# Altium schematic sheet CAN_RS485.SchDoc(CAN_RS485)
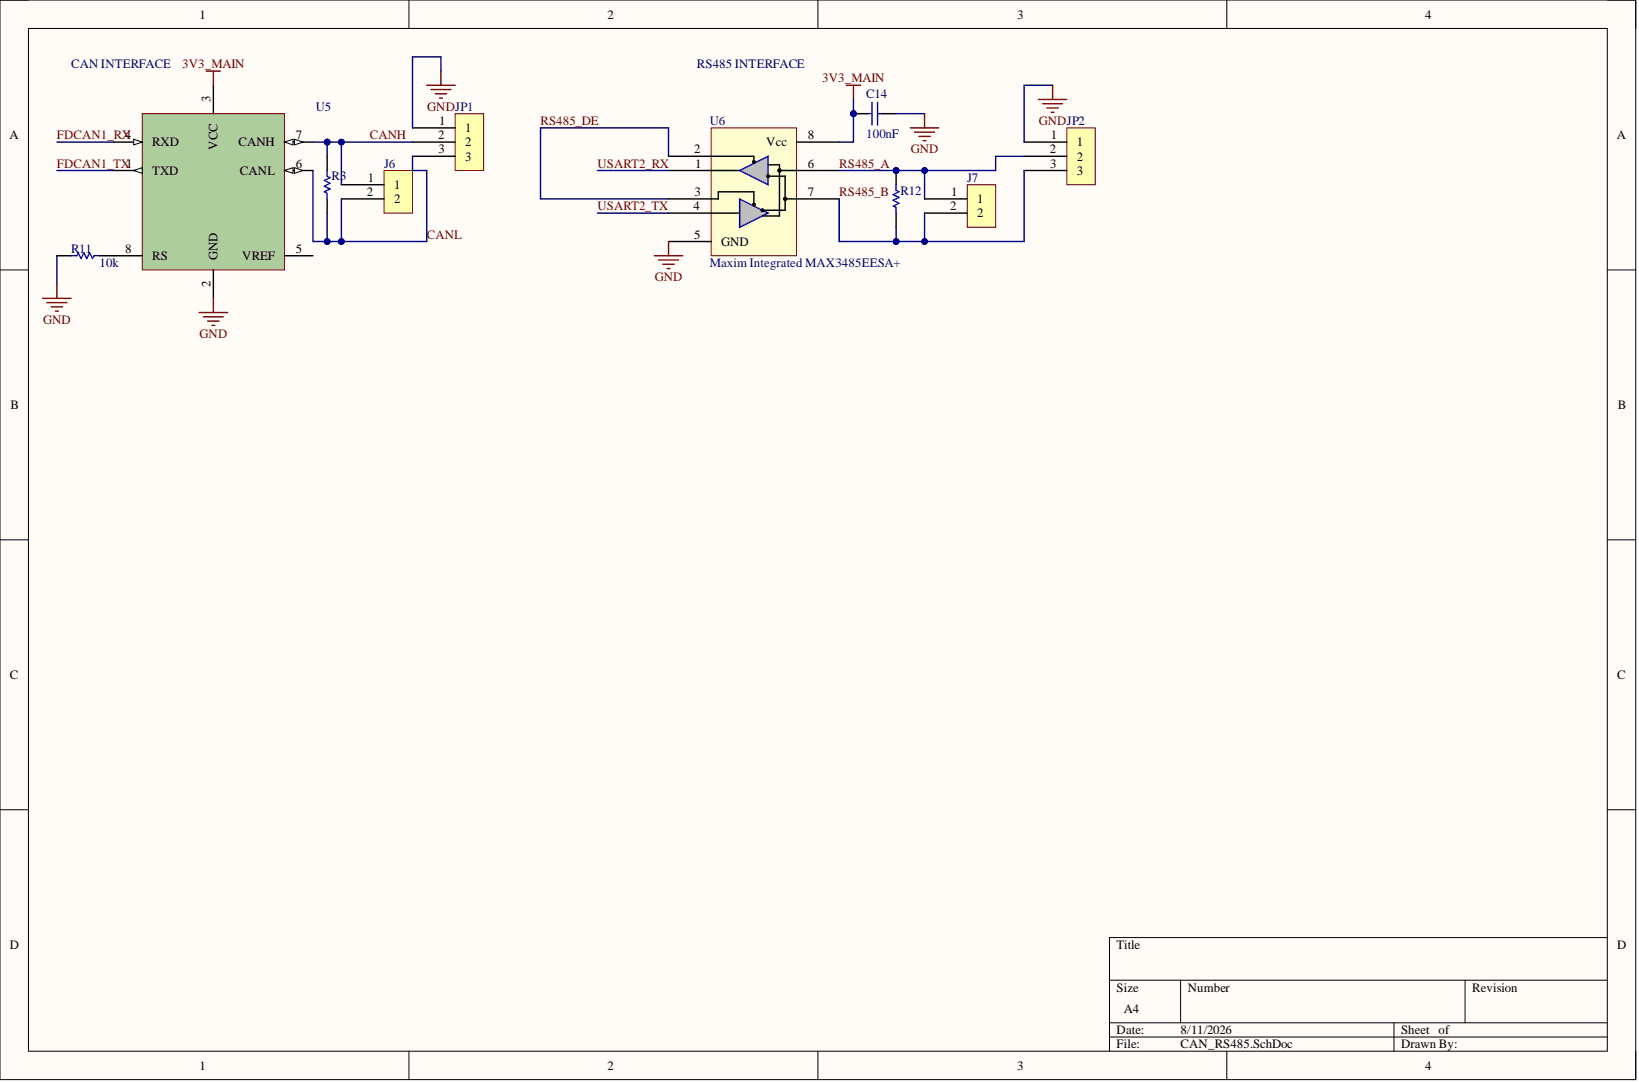
[diagram p0: CAN_RS485.SchDoc]

## Parts
C14  100nF
J6  Harwin M50-3530242  [PCB-kdbzezog7lgzeuckpa59-1]  (value not drawn)
J7  Harwin M50-3530242  [PCB-kdbzezog7lgzeuckpa59-1]  (value not drawn)
JP1  Amphenol ICC / FCI 68000-103HLF  [PCB-q1cedbed5xdsdy41e762-1]  (value not drawn)
JP2  Amphenol ICC / FCI 68000-103HLF  [PCB-q1cedbed5xdsdy41e762-1]  (value not drawn)
R3  Yageo RC0603FR-07120RL  (value not drawn)
R11  10k
R12  Yageo RC0603FR-07120RL  (value not drawn)
U5  Texas Instruments (TI) SN65HVD230DR  (value not drawn)
U6  Maxim Integrated MAX3485EESA+  Manufacturer Part Number: MAX3485EESA+  [PCB-ckpkxznd73w9x719u7e5-1]

## Nets
3V3_MAIN: C14.1 U5.3(VCC) U6.8(Vcc)
GND: C14.2 JP1.1 JP2.1 R11.2 U5.2(GND) U6.5(GND)
CANH: J6.1 JP1.2 R3.2 U5.7(CANH)
CANL: J6.2 JP1.3 R3.1 U5.6(CANL)
FDCAN1_RX: U5.4(RXD)
FDCAN1_TX: U5.1(TXD)
RS485_A: J7.1 JP2.2 R12.1 U6.6
RS485_B: J7.2 JP2.3 R12.2 U6.7
RS485_DE: U6.2 U6.3
USART2_RX: U6.1
USART2_TX: U6.4
NetR11_1: R11.1 U5.8(RS)

Not connected: U5 5(VREF)

## Library data of the parts
C14 = CMP-e7d62c864ecd3811-3: Capacitor; ECWF, Met Polypropylene Film Cap, Radial, 50V, 10uF, 5%, Blk ST; Manufacturer Part Number: ECW-F4474JL; Capacitance: 470 nF; Lead Length: 25.0 mm; Lead-Free Status: Lead Free; Capacitance Tolerance: ±5%; Component Kind: Standard; Dielectric Material: Polypropylene; Library Name: My Personal Space; Lifecycle Status: Not Listed by Manufacturer; Mounting Style: Through Hole; Octopart Url: https://octopart.com/ecw-f4474jl-panasonic-39488637; OctopartID: e7d62c864ecd3811; Operating Temperature: 105 °C (max); Packaging: Bulk; RoHS: Compliant; Size-Height: 5mm; Size-Length: 11mm; Voltage Rating (DC): 50v
J6 J7 = CMP-8a7247a62d3655b5-1: Prices include import duty and tax. BTB CONN, HEADER, 2POS, 1ROWS, 1.27MM; Component Kind: Standard; Library Name: My Personal Space; Manufacturer Part Number: M50-3530242; Octopart URL: https://octopart.com/m50-3530242-harwin-81691170; OctopartID: 8a7247a62d3655b5
JP1 JP2 = CMP-8ffa44933221503d-6: Conn Unshrouded Header HDR 3 POS 2.54mm Solder ST Top Entry Thru-Hole BergStik® Bulk; Gender: Male; Lead-Free Status: Lead Free; Number of Contacts: 3; Component Kind: Standard; Contact Material: Phosphor Bronze; Contact Plating: Gold; Library Name: My Personal Space; Manufacturer Part Number: 68000-103HLF; Mounting Style: Through Hole; Number of Positions: 3; Number of Rows: 1; Octopart URL: https://octopart.com/68000-103hlf-amphenol+icc+%2F+fci-90333677; OctopartID: 3f01f3697b8ae0c6; Orientation: Straight; Packaging: Bulk; Pin Pitch: 2.54 mm; RoHS: Compliant
R3 R12 = CMP-1900331-5: Chip Resistor, 120 Ohm, +/- 1%, 100 mW, -55 to 155 degC, 0603 (1608 Metric), RoHS, Tape and Reel; Case/Package (SI): 1608; Lead-Free Status: Lead Free; Power Rating: 100 mW; Resistance: 120 Ω; Voltage Rating (DC): 50.0 V; Case/Package: 0603; Component Kind: Standard; DatasheetVersion: Rev. 4, 02/2016; Library Name: My Personal Space; Manufacturer Part Number: RC0603FR-07120RL; Mounting Style: Surface Mount; Number of Pins: 2; Octopart Url: http://octopart.com/rc0603fr-07120rl-yageo-40254891; OctopartID: 43bd88d15b709c7a; PackageDescription: 2-Pin Surface Mount Device, Body 1.6 x 0.8 mm; PackageReference: 0603; Packaging: Cut Tape (CT), Tape & Reel (TR); REACH SVHC Compliance: No SVHC; Resistance Tolerance: ±1%; RoHS: Compliant; Seller Landing  Url: https://octopart-clicks.com/click/track?country=US&ak=8157f166&sig=0ad1bcb&sid=1106&ppid=40254891&vpid=198667073&ct=offers; Size-Height: 450 µm; Size-Length: 1.60 mm; Size-Width: 800 µm
R11 = CMP-3651607-3: Thick Film Resistors - SMD 0402 47ohms 5% Tol; Manufacturer Part Number: ERJ-2GEJ470X; Lead-Free Status: Lead Free; Power Rating: 0.1 W; Resistance: 47.0 Ω; Voltage Rating (DC): 50.0 V; Case/Package: 0402; Component Kind: Standard; Library Name: My Personal Space; Lifecycle Status: Not Listed by Manufacturer; Mounting Style: Surface Mount; Octopart Url: https://octopart.com/erj-2gej470x-panasonic-55420769; OctopartID: 7e7eb439fc79a1b9; Packaging: Cut Tape (CT); REACH SVHC Compliance: No SVHC; Resistance Tolerance: ±5%; RoHS: Compliant; Size-Height: 0.00035 m; Size-Length: 0.001 m; Size-Width: 0.0005 m
U5 = CMP-19361092-6: 3.3 V CAN Transceiver with Standby Mode 8-SOIC -40 to 85; Data Rate: 1.00 Mbps; Drivers Per Package: 1; Lead-Free Status: Lead Free; Slew Rate: 15.0 V/μs; Supply Current: 10.0 mA; Supply Voltage (DC): 3.00 V to 3.60 V; Case/Package: SOIC; Component Kind: Standard; Library Name: My Personal Space; Lifecycle Status: Active; Manufacturer Part Number: SN65HVD230DR; Mounting Style: Surface Mount; Number of Pins: 8; Octopart Url: http://octopart.com/sn65hvd230dr-texas+instruments-430101; OctopartID: 91a658aa24d54e61; Packaging: Cut Tape (CT), Tape & Reel (TR); REACH SVHC Compliance: No SVHC; RoHS: Compliant; Seller Landing  Url: https://octopart-clicks.com/click/track?country=US&ak=8157f166&sig=022641b&sid=1106&ppid=430101&vpid=21056751&ct=offers
U6 = CMP-5f72942638ab80b5-1: MAX3485E Series 12 Mbps 3.6 V Half-Duplex SMT RS 485 Transceiver - SOIC-8; Data Rate: 15.0 Mbps; Lead-Free Status: Lead Free; Supply Current: 1.10 mA; Supply Voltage (DC): 3.60 V (max), 3.00 V (min), 3.30 V (max); Case/Package: SOIC; Component Kind: Standard; Library Name: My Personal Space; Lifecycle Status: Not Listed by Manufacturer; Mounting Style: Surface Mount; Number of Pins: 8; Octopart URL: https://octopart.com/max3485eesa%2B-maxim+integrated-39731903; OctopartID: 5f72942638ab80b5; Operating Temperature: -40.0 °C to 85.0 °C; Packaging: Tube; RoHS: Compliant
